# Altium schematic sheet PROCESSOR.SchDoc
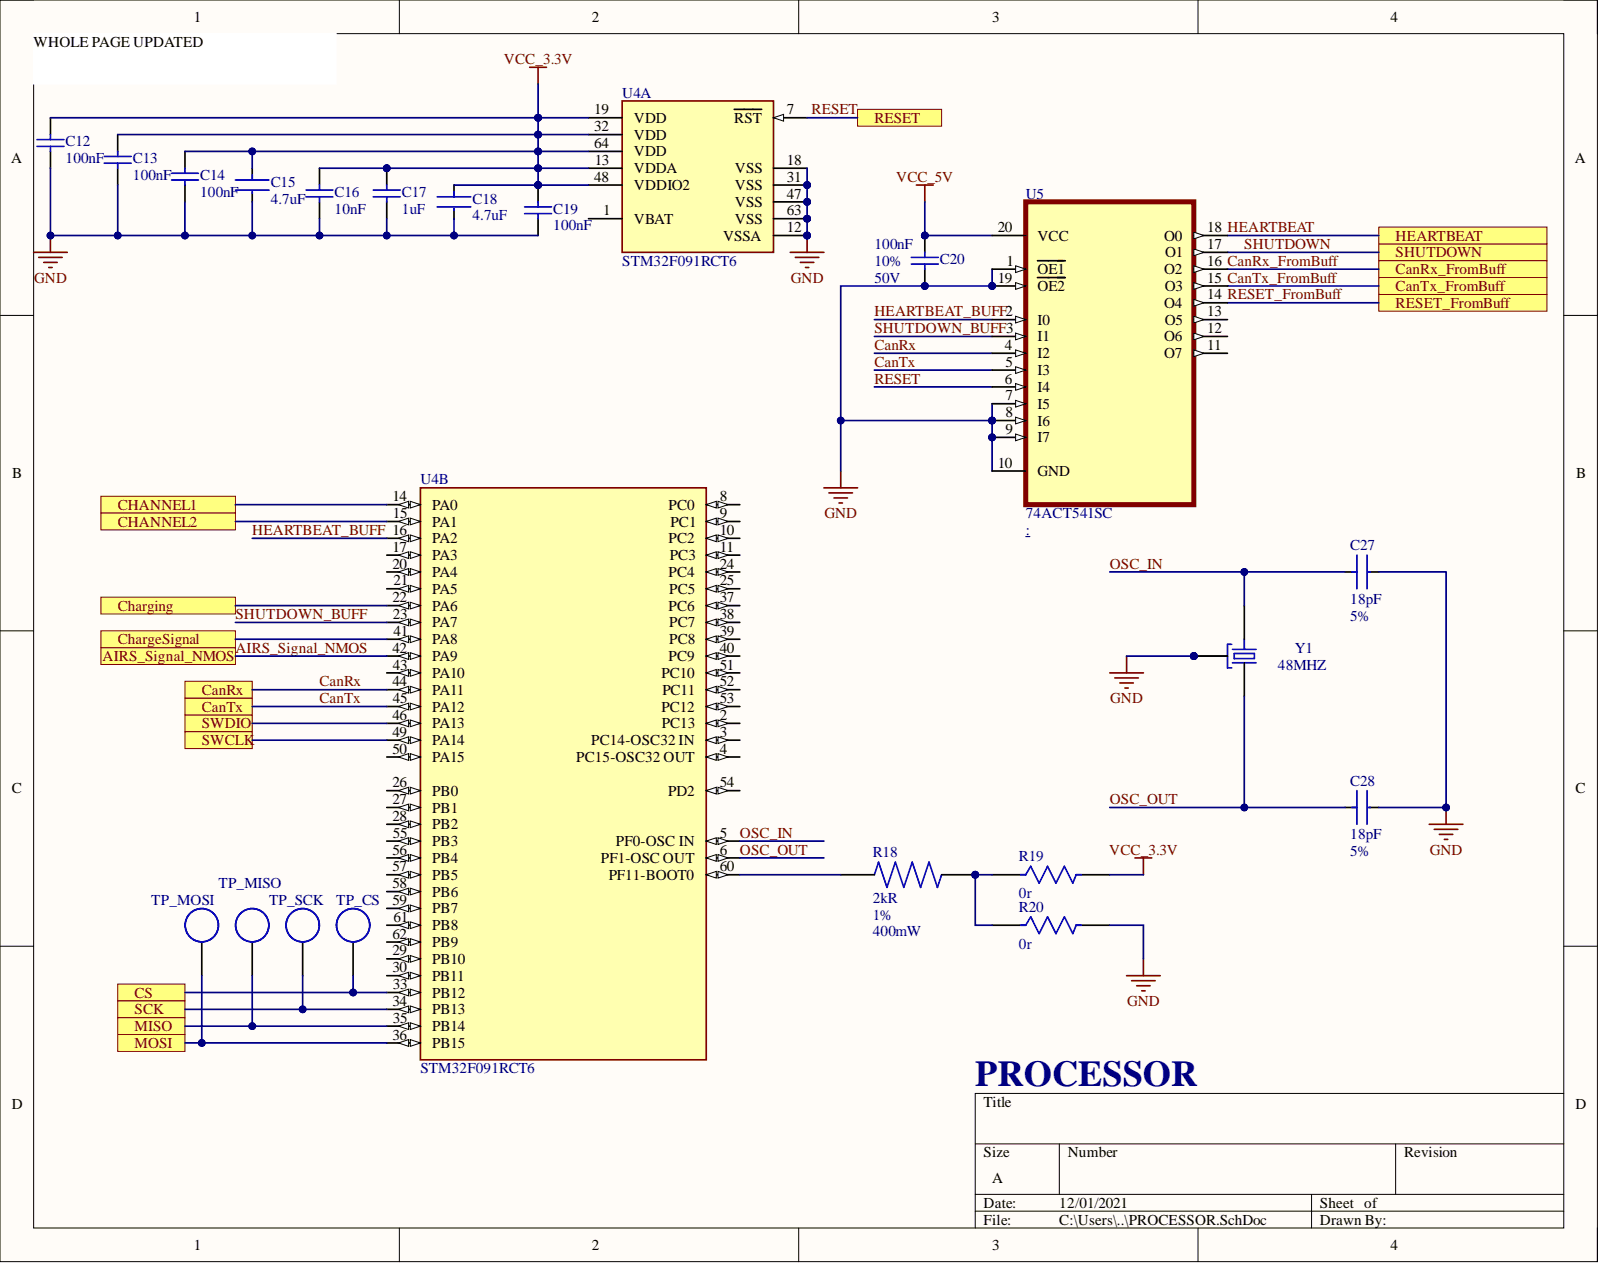
[diagram p0: PROCESSOR.SchDoc]

## Parts
C12  100nF  [CAPC2013X145X45NL30T25]
C13  100nF  [CAPC2013X145X45NL30T25]
C14  100nF  [CAPC2013X145X45NL30T25]
C15  4.7uF  [FP-GCM21B-0_15-IPC_A]
C16  10nF  [CAPC2013X70X45LL15T25]
C17  1uF  [CAPC2013X70X45NL10T25]
C18  4.7uF  [FP-GCM21B-0_15-IPC_A]
C19  100nF  [CAPC2013X145X45NL30T25]
C20  100nF  Tolerance: 10%  Voltage Rating: 50V  [CAPC2013X145X45NL30T25]
C27  18pF  Tolerance: 5%  [FP-GQM219-0_15-MFG]
C28  18pF  Tolerance: 5%  [FP-GQM219-0_15-MFG]
R18  Resistance: 2kR  Tolerance: 1%  [RESC2012X50N]  (hidden comment: 2k, 1%, 0.4W)
R19  0r  [FP-CRCW0805-HPe3-IPC_A]
R20  0r  [FP-CRCW0805-HPe3-IPC_A]
TP_CS  5015  [5015]  (value not drawn)
TP_MISO  5015  [5015]  (value not drawn)
TP_MOSI  5015  [5015]  (value not drawn)
TP_SCK  5015  [5015]  (value not drawn)
U4  STM32F091RCT6  [TQFP64]  (parts A,B)
U5  74ACT541SC  [SOIC127P1032X265-20N]
Y1  48MHZ  [OSCCC500X320X110-4N]

## Ports
AIRS_Signal_NMOS, CanRx, CanRx_FromBuff, CanTx, CanTx_FromBuff, CHANNEL1, CHANNEL2, ChargeSignal, Charging, CS, HEARTBEAT, MISO, MOSI, RESET, RESET_FromBuff, SCK, SHUTDOWN, SWCLK, SWDIO

## Nets
GND: C12.1 C13.1 C14.1 C15.2 C16.1 C17.1 C18.2 C19.1 C20.1 C27.2 C28.2 R20.2 U4.12(VSSA) U4.18(VSS) U4.31(VSS) U4.47(VSS) U4.63(VSS) U5.1(OE1) U5.7(I5) U5.8(I6) U5.9(I7) U5.10(GND) U5.19(OE2) Y1.2 Y1.4
VCC_3.3V: C12.2 C13.2 C14.2 C15.1 C16.2 C17.2 C18.1 C19.2 R19.2 U4.13(VDDA) U4.19(VDD) U4.32(VDD) U4.48(VDDIO2) U4.64(VDD)
VCC_5V: C20.2 U5.20(VCC)
AIRS_Signal_NMOS: U4.42(PA9) ; port AIRS_Signal_NMOS
CanRx: U4.44(PA11) U5.4(I2) ; port CanRx
CanRx_FromBuff: U5.16(O2) ; port CanRx_FromBuff
CanTx: U4.45(PA12) U5.5(I3) ; port CanTx
CanTx_FromBuff: U5.15(O3) ; port CanTx_FromBuff
CHANNEL1: U4.14(PA0) ; port CHANNEL1
CHANNEL2: U4.15(PA1) ; port CHANNEL2
ChargeSignal: U4.41(PA8) ; port ChargeSignal
Charging: U4.22(PA6) ; port Charging
CS: TP_CS.1 U4.33(PB12) ; port CS
HEARTBEAT: U5.18(O0) ; port HEARTBEAT
HEARTBEAT_BUFF: U4.16(PA2) U5.2(I0)
MISO: TP_MISO.1 U4.35(PB14) ; port MISO
MOSI: TP_MOSI.1 U4.36(PB15) ; port MOSI
OSC_IN: C27.1 U4.5(PF0-OSC_IN) Y1.1
OSC_OUT: C28.1 U4.6(PF1-OSC_OUT) Y1.3
RESET: U4.7(RST) U5.6(I4) ; port RESET
RESET_FromBuff: U5.14(O4) ; port RESET_FromBuff
SCK: TP_SCK.1 U4.34(PB13) ; port SCK
SHUTDOWN: U5.17(O1) ; port SHUTDOWN
SHUTDOWN_BUFF: U4.23(PA7) U5.3(I1)
SWCLK: U4.49(PA14) ; port SWCLK
SWDIO: U4.46(PA13) ; port SWDIO
NetR18_1: R18.1 U4.60(PF11-BOOT0)
NetR18_2: R18.2 R19.1 R20.1

Not connected: U4 1(VBAT) 2(PC13) 3(PC14-OSC32_IN) 4(PC15-OSC32_OUT) 8(PC0) 9(PC1) 10(PC2) 11(PC3) 17(PA3) 20(PA4) 21(PA5) 24(PC4) 25(PC5) 26(PB0) 27(PB1) 28(PB2) 29(PB10) 30(PB11) 37(PC6) 38(PC7) 39(PC8) 40(PC9) 43(PA10) 50(PA15) 51(PC10) 52(PC11) 53(PC12) 54(PD2) 55(PB3) 56(PB4) 57(PB5) 58(PB6) 59(PB7) 61(PB8) 62(PB9); U5 11(O7) 12(O6) 13(O5)

## Library data of the parts
C12-C14 C19 = CMP-2007-03212-1: Manufacturer: Murata; Manufacturer Part Number 1: GCJ21BR71H104KA01L; Dielectric: X7R; Tolerance: 10%; Voltage Rating: 50V; Capacitance: 100nF; Case Code (Imperial): 0805; Case Code (Metric): 2012; Case/Package: 0805; Category: Ceramic Capacitors; Component Kind: Standard; ComponentLink1Description: https://datasheet.ciiva.com/17453/product-17453782.pdf?src-supplier=Avnet+Europe; ComponentLink1URL: https://datasheet.ciiva.com/17453/product-17453782.pdf?src-supplier=Avnet+Europe; ComponentLink2Description: https://datasheet.ciiva.com/18183/getdatasheetpartid-663717-18183469.pdf?src-supplier=Verical; ComponentLink2URL: https://datasheet.ciiva.com/18183/getdatasheetpartid-663717-18183469.pdf?src-supplier=Verical; ComponentLink3Description: https://datasheet.ciiva.com/136/s0717e-136749.pdf?src-supplier=Digi-Key; ComponentLink3URL: https://datasheet.ciiva.com/136/s0717e-136749.pdf?src-supplier=Digi-Key; ComponentLink4Description: https://datasheet.ciiva.com/136/s0717e-136750.pdf?src-supplier=Digi-Key; ComponentLink4URL: https://datasheet.ciiva.com/136/s0717e-136750.pdf?src-supplier=Digi-Key; ComponentLink5Description: https://datasheet.ciiva.com/136/s0717e-136751.pdf?src-supplier=Digi-Key; ComponentLink5URL: https://datasheet.ciiva.com/136/s0717e-136751.pdf?src-supplier=Digi-Key; Construction: Flat; Depth: 1.25mm; Features: Soft Termination; Height: 1.25mm; Lead Free: Lead Free; Length: 2mm; Library Name: Altium Content Vault; Manufacturer 1: Murata; Max Operating Temperature: 125°C; Min Operating Temperature: -55°C; Mount: Surface Mount; Package Quantity: 3000; Packaging: Tape and Reel; Part Number: GCJ21BR71H104KA01L; Pricing 1: 1=0.48000, 10=0.34200, 50=0.25880, 100=0.22540, 500=0.16698, 1000=0.14193 (USD); Radiation Hardening: No; Ratings: AEC-Q200; RoHS: Yes; RoHS Compliant: Yes; Series: GCJ; Stock 1: 105376; Supplier 1: Digi-Key; Supplier Part Number 1: 490-5803-1-ND; Termination: SMD/SMT; Thickness: 0.057inch; Voltage Rating (DC): 50V; Width: 0.049inch
C15 C18 = CMP-2007-03343-2: Ceramic Capacitor for Automotive 4.7uF ±10% 16VDC X7R 0805 Embossed T/R; Manufacturer 1: Murata; Manufacturer Part Number 1: GCM21BR71C475KA73L; Voltage: 16V; Capacitance: 4.7uF; Case Code (Imperial): 0805; Case Code (Metric): 2012; Case/Package: 0805; Category: Ceramic Capacitors; Component Kind: Standard; ComponentLink1Description: https://datasheet.ciiva.com/11613/product-11613410.pdf?src-supplier=Avnet; ComponentLink1URL: https://datasheet.ciiva.com/11613/product-11613410.pdf?src-supplier=Avnet; ComponentLink2Description: https://datasheet.ciiva.com/11787/0900766b80ef92d0-11787002.pdf?src-supplier=RSComponents; ComponentLink2URL: https://datasheet.ciiva.com/11787/0900766b80ef92d0-11787002.pdf?src-supplier=RSComponents; ComponentLink3Description: https://datasheet.ciiva.com/140/s0717e-140483.pdf?src-supplier=Digi-Key; ComponentLink3URL: https://datasheet.ciiva.com/140/s0717e-140483.pdf?src-supplier=Digi-Key; ComponentLink4Description: https://datasheet.ciiva.com/140/s0717e-140484.pdf?src-supplier=Digi-Key; ComponentLink4URL: https://datasheet.ciiva.com/140/s0717e-140484.pdf?src-supplier=Digi-Key; Construction: Flat; Depth: 1.25mm; Dielectric: X7R; Height: 1.25mm; Lead Free: Lead Free; Length: 2mm; Library Name: Altium Content Vault; Max Operating Temperature: 125°C; Min Operating Temperature: -55°C; Mount: Surface Mount; Package Quantity: 1; Packaging: Tape and Reel; Pricing 1: 1=0.37000, 10=0.25300, 50=0.16900, 100=0.14360, 500=0.10138, 1000=0.08870 (USD); Radiation Hardening: No; Ratings: AEC-Q200; REACH SVHC: No SVHC; RoHS: Yes; RoHS Compliant: Yes; Series: GCM; Stock 1: 382617; Supplier 1: Digi-Key; Supplier Part Number 1: 490-5332-1-ND; Termination: SMD/SMT; Thickness: 0.055inch; Tolerance: 10%; Voltage Rating: 16V; Voltage Rating (DC): 16V; Weight: 0.000335oz; Width: 0.049inch
C16 = CMP-2007-00806-1: Manufacturer: Murata; Manufacturer Part Number 1: GCM216R72A103KA37D; Dielectric: X7R; Tolerance: 10%; Voltage: 100V; Capacitance: 10nF; Case Code (Imperial): 0805; Case Code (Metric): 2012; Case/Package: 0805; Category: Ceramic Capacitors; Component Kind: Standard; ComponentLink1Description: https://datasheet.ciiva.com/11620/product-11620072.pdf?src-supplier=Avnet; ComponentLink1URL: https://datasheet.ciiva.com/11620/product-11620072.pdf?src-supplier=Avnet; ComponentLink2Description: https://datasheet.ciiva.com/18183/getdatasheetpartid-223896-18183680.pdf?src-supplier=Verical; ComponentLink2URL: https://datasheet.ciiva.com/18183/getdatasheetpartid-223896-18183680.pdf?src-supplier=Verical; ComponentLink3Description: https://datasheet.ciiva.com/6270/s0717e-6270558.pdf?src-supplier=Digi-Key; ComponentLink3URL: https://datasheet.ciiva.com/6270/s0717e-6270558.pdf?src-supplier=Digi-Key; ComponentLink4Description: https://datasheet.ciiva.com/16214/s0717e-16214647.pdf?src-supplier=Future+Electronics; ComponentLink4URL: https://datasheet.ciiva.com/16214/s0717e-16214647.pdf?src-supplier=Future+Electronics; Construction: Flat; Depth: 1.25mm; Height: 0.6mm; Lead Free: Lead Free; Length: 2mm; Library Name: Altium Content Vault; Manufacturer 1: Murata; Max Operating Temperature: 125°C; Min Operating Temperature: -55°C; Mount: Surface Mount; Package Quantity: 1; Packaging: Tape and Reel; Part Number: GCM216R72A103KA37D; Pricing 1: 1=0.36000, 10=0.24900, 50=0.16640, 100=0.14140, 500=0.09980, 1000=0.08732 (USD); Radiation Hardening: No; Ratings: AEC-Q200; RoHS: Yes; RoHS Compliant: Yes; Series: GCM; Stock 1: 2316; Supplier 1: Digi-Key; Supplier Part Number 1: 490-6060-1-ND; Termination: SMD/SMT; Thickness: 0.028inch; Voltage Rating: 100V; Voltage Rating (DC): 100V; Width: 0.049inch
C17 = CMP-2007-00957-1: Manufacturer: Murata; Manufacturer Part Number 1: GRM216R61E105KA12D; Dielectric: X5R; Material: Ceramic; Tolerance: 10%; Voltage: 25V; Capacitance: 1uF; Case Code (Imperial): 0805; Case Code (Metric): 2012; Case/Package: 0805; Category: Ceramic Capacitors; Component Kind: Standard; ComponentLink1Description: https://datasheet.ciiva.com/5424/product-5424880.pdf?src-supplier=Mouser; ComponentLink1URL: https://datasheet.ciiva.com/5424/product-5424880.pdf?src-supplier=Mouser; ComponentLink2Description: https://datasheet.ciiva.com/3777/337grm216r61e105ka1223-3777289.pdf?src-supplier=Arrow+Electronics; ComponentLink2URL: https://datasheet.ciiva.com/3777/337grm216r61e105ka1223-3777289.pdf?src-supplier=Arrow+Electronics; ComponentLink3Description: https://datasheet.ciiva.com/11787/0900766b80ef921d-11787891.pdf?src-supplier=RSComponents; ComponentLink3URL: https://datasheet.ciiva.com/11787/0900766b80ef921d-11787891.pdf?src-supplier=RSComponents; ComponentLink4Description: https://datasheet.ciiva.com/138/partnumbering-e-01-138517.pdf?src-supplier=Digi-Key; ComponentLink4URL: https://datasheet.ciiva.com/138/partnumbering-e-01-138517.pdf?src-supplier=Digi-Key; ComponentLink5Description: https://datasheet.ciiva.com/138/partnumbering-e-01-138519.pdf?src-supplier=Digi-Key; ComponentLink5URL: https://datasheet.ciiva.com/138/partnumbering-e-01-138519.pdf?src-supplier=Digi-Key; ComponentLink6Description: https://datasheet.ciiva.com/138/partnumbering-e-01-138518.pdf?src-supplier=Digi-Key; ComponentLink6URL: https://datasheet.ciiva.com/138/partnumbering-e-01-138518.pdf?src-supplier=Digi-Key; ComponentLink7Description: https://datasheet.ciiva.com/2989/product-2989638.pdf?src-supplier=Avnet; ComponentLink7URL: https://datasheet.ciiva.com/2989/product-2989638.pdf?src-supplier=Avnet; ComponentLink8Description: https://datasheet.ciiva.com/22706/1889704-22706791.pdf?src-supplier=Element14; ComponentLink8URL: https://datasheet.ciiva.com/22706/1889704-22706791.pdf?src-supplier=Element14; ComponentLink9Description: https://datasheet.ciiva.com/7719/c02e-7719049.pdf?src-supplier=Element14; ComponentLink9URL: https://datasheet.ciiva.com/7719/c02e-7719049.pdf?src-supplier=Element14; Construction: Flat; Depth: 1.25mm; Height: 0.6mm; Lead Free: Lead Free; Length: 2mm; Library Name: Altium Content Vault; Manufacturer 1: Murata; Max Operating Temperature: 85°C; Min Operating Temperature: -55°C; Mount: Surface Mount; Number of Pins: 2; Package Quantity: 1; Packaging: Tape and Reel; Part Number: GRM216R61E105KA12D; Pricing 1: 1=0.40000, 10=0.27800, 50=0.19480, 100=0.16700, 500=0.12524, 1000=0.10575 (USD); Radiation Hardening: No; REACH SVHC: No SVHC; RoHS: Yes; RoHS Compliant: Yes; Series: GRM; Stock 1: 839705; Supplier 1: Digi-Key; Supplier Part Number 1: 490-1700-1-ND; Termination: SMD/SMT; Thickness: 0.028inch; Voltage Rating: 25V; Voltage Rating (DC): 25V; Width: 1.25mm
C20 = CMP-2007-03212-1: Manufacturer: Murata; Manufacturer Part Number 1: GCJ21BR71H104KA01L; Dielectric: X7R; Capacitance: 100nF; Case Code (Imperial): 0805; Case Code (Metric): 2012; Case/Package: 0805; Category: Ceramic Capacitors; Component Kind: Standard; ComponentLink1Description: https://datasheet.ciiva.com/17453/product-17453782.pdf?src-supplier=Avnet+Europe; ComponentLink1URL: https://datasheet.ciiva.com/17453/product-17453782.pdf?src-supplier=Avnet+Europe; ComponentLink2Description: https://datasheet.ciiva.com/18183/getdatasheetpartid-663717-18183469.pdf?src-supplier=Verical; ComponentLink2URL: https://datasheet.ciiva.com/18183/getdatasheetpartid-663717-18183469.pdf?src-supplier=Verical; ComponentLink3Description: https://datasheet.ciiva.com/136/s0717e-136749.pdf?src-supplier=Digi-Key; ComponentLink3URL: https://datasheet.ciiva.com/136/s0717e-136749.pdf?src-supplier=Digi-Key; ComponentLink4Description: https://datasheet.ciiva.com/136/s0717e-136750.pdf?src-supplier=Digi-Key; ComponentLink4URL: https://datasheet.ciiva.com/136/s0717e-136750.pdf?src-supplier=Digi-Key; ComponentLink5Description: https://datasheet.ciiva.com/136/s0717e-136751.pdf?src-supplier=Digi-Key; ComponentLink5URL: https://datasheet.ciiva.com/136/s0717e-136751.pdf?src-supplier=Digi-Key; Construction: Flat; Depth: 1.25mm; Features: Soft Termination; Height: 1.25mm; Lead Free: Lead Free; Length: 2mm; Library Name: Altium Content Vault; Manufacturer 1: Murata; Max Operating Temperature: 125°C; Min Operating Temperature: -55°C; Mount: Surface Mount; Package Quantity: 3000; Packaging: Tape and Reel; Part Number: GCJ21BR71H104KA01L; Pricing 1: 1=0.48000, 10=0.34200, 50=0.25880, 100=0.22540, 500=0.16698, 1000=0.14193 (USD); Radiation Hardening: No; Ratings: AEC-Q200; RoHS: Yes; RoHS Compliant: Yes; Series: GCJ; Stock 1: 105376; Supplier 1: Digi-Key; Supplier Part Number 1: 490-5803-1-ND; Termination: SMD/SMT; Thickness: 0.057inch; Voltage Rating (DC): 50V; Width: 0.049inch
C27 C28 = CMP-2007-03884-2: Ceramic Capacitor 18pF ±5% 250VDC C0G 0805 Paper T/R; Manufacturer 1: Murata; Manufacturer Part Number 1: GQM2195C2E180JB12D; Voltage Rating: 250V; Capacitance: 18pF; Case Code (Imperial): 0805; Case Code (Metric): 2012; Case/Package: 0805; Category: Ceramic Capacitors; Component Kind: Standard; ComponentLink1Description: https://datasheet.ciiva.com/5420/product-5420568.pdf?src-supplier=Mouser; ComponentLink1URL: https://datasheet.ciiva.com/5420/product-5420568.pdf?src-supplier=Mouser; ComponentLink2Description: https://datasheet.ciiva.com/142/partnumbering-e-01-142348.pdf?src-supplier=Digi-Key; ComponentLink2URL: https://datasheet.ciiva.com/142/partnumbering-e-01-142348.pdf?src-supplier=Digi-Key; ComponentLink3Description: https://datasheet.ciiva.com/142/partnumbering-e-01-142349.pdf?src-supplier=Digi-Key; ComponentLink3URL: https://datasheet.ciiva.com/142/partnumbering-e-01-142349.pdf?src-supplier=Digi-Key; ComponentLink4Description: https://datasheet.ciiva.com/142/partnumbering-e-01-142350.pdf?src-supplier=Digi-Key; ComponentLink4URL: https://datasheet.ciiva.com/142/partnumbering-e-01-142350.pdf?src-supplier=Digi-Key; ComponentLink5Description: https://datasheet.ciiva.com/11607/product-11607802.pdf?src-supplier=Avnet; ComponentLink5URL: https://datasheet.ciiva.com/11607/product-11607802.pdf?src-supplier=Avnet; Construction: Flat; Depth: 1.25mm; Dielectric: C0G; Height: 0.85mm; Length: 2mm; Library Name: Altium Content Vault; Max Operating Temperature: 125°C; Min Operating Temperature: -55°C; Mount: Surface Mount; Package Quantity: 1; Packaging: Tape and Reel; Pricing 1: 1=1.00000, 10=0.75900, 50=0.63080, 100=0.54320, 500=0.42056, 1000=0.36214 (USD); Radiation Hardening: No; RoHS: Yes; RoHS Compliant: Yes; Series: GQM; Stock 1: 3729; Supplier 1: Digi-Key; Supplier Part Number 1: 490-5297-1-ND; Termination: SMD/SMT; Thickness: 0.039inch; Voltage Rating (DC): 250V; Width: 1.25mm
R18 = RCS08052K00FKEA: Res Thick Film 0805 2K Ohm 1% 0.4W ±100ppm/C Pad SMD Automotive T/R; Manufacturer 1: Vishay Dale; Max Power Dissipation: 400mW; Temperature Coefficient: 100ppm/°C; Availability: Unavailable; Case Code (Imperial): 0805; Case Code (Metric): 2012; Case/Package: 0805; Category: Chip SMD Resistors; Component Kind: Standard; ComponentLink1Description: http://www.vishay.com/docs/20065/rcse3.pdf?src-supplier=Digi-Key; ComponentLink1URL: http://www.vishay.com/docs/20065/rcse3.pdf?src-supplier=Digi-Key; Features: Automotive AEC-Q200; Height: 0.02inch; Length: 0.079inch; Manufacturer Part Number 1: RCS08052K00FKEA; Max Operating Temperature: 155°C; Min Operating Temperature: -55°C; MP: RCS08052K00FKEA; Number of Terminations: 2; Package: None; Packaging: Tape and Reel; Price: None; Pricing 1: 1=0.26000, 10=0.21800, 100=0.08510, 1000=0.03560, 2500=0.03264 (USD); Resistor Type: Thick Film; RoHS: Yes; Stock 1: 4243; Supplier 1: Digi-Key; Supplier Part Number 1: 541-2840-1-ND; Width: 0.049inch; Library Name: BMS_MasterboardUpdated_1.SCHLIB
R19 R20 = CMP-2001-04185-2: RES Thick Film, 0Ω, 0.5W, 0805; Manufacturer 1: Vishay Dale; Manufacturer Part Number 1: CRCW08050000Z0EAHP; Max Power Dissipation: 500mW; Power Rating: 330mW; Resistance: 0mR; Temperature Coefficient: 0ppm/°C; Tolerance: 5%; Voltage Rating: 150V; Case Code (Imperial): 0805; Case Code (Metric): 2012; Case/Package: 0805; Category: Chip SMD Resistors; Component Kind: Standard; ComponentLink1Description: https://datasheet.ciiva.com/2474/crcwhpe3-2474632.pdf?src-supplier=Digi-Key; ComponentLink1URL: https://datasheet.ciiva.com/2474/crcwhpe3-2474632.pdf?src-supplier=Digi-Key; ComponentLink2Description: https://datasheet.ciiva.com/2474/crcwhpe3-2474633.pdf?src-supplier=Digi-Key; ComponentLink2URL: https://datasheet.ciiva.com/2474/crcwhpe3-2474633.pdf?src-supplier=Digi-Key; ComponentLink3Description: https://datasheet.ciiva.com/2474/crcwhpe3-2474634.pdf?src-supplier=Digi-Key; ComponentLink3URL: https://datasheet.ciiva.com/2474/crcwhpe3-2474634.pdf?src-supplier=Digi-Key; ComponentLink4Description: https://datasheet.ciiva.com/9109/crcwhpe3-9109934.pdf?src-supplier=Avnet; ComponentLink4URL: https://datasheet.ciiva.com/9109/crcwhpe3-9109934.pdf?src-supplier=Avnet; ComponentLink5Description: https://datasheet.ciiva.com/5549/crcwhpe3-46056-5549241.pdf?src-supplier=Mouser; ComponentLink5URL: https://datasheet.ciiva.com/5549/crcwhpe3-46056-5549241.pdf?src-supplier=Mouser; ComponentLink6Description: https://datasheet.ciiva.com/16883/crcwhpe3-16883643.pdf?src-supplier=Avnet+Europe; ComponentLink6URL: https://datasheet.ciiva.com/16883/crcwhpe3-16883643.pdf?src-supplier=Avnet+Europe; ComponentLink7Description: https://datasheet.ciiva.com/16498/crcwhpe3-16498294.pdf?src-supplier=Future+Electronics; ComponentLink7URL: https://datasheet.ciiva.com/16498/crcwhpe3-16498294.pdf?src-supplier=Future+Electronics; ComponentLink8Description: https://datasheet.ciiva.com/28621/1750852-28621406.pdf?src-supplier=Element14; ComponentLink8URL: https://datasheet.ciiva.com/28621/1750852-28621406.pdf?src-supplier=Element14; ComponentLink9Description: https://datasheet.ciiva.com/7859/crcwhpe3-7859727.pdf?src-supplier=Element14; ComponentLink9URL: https://datasheet.ciiva.com/7859/crcwhpe3-7859727.pdf?src-supplier=Element14; Composition: Thick Film; Construction: Rectangular; Contact Plating: Tin; Depth: 1.25mm; Features: Automotive AEC-Q200; Height: 0.024inch; Lead Free: Lead Free; Length: 2mm; Library Name: Altium Content Vault; Max Operating Temperature: 155°C; Military Standard: Not; Min Operating Temperature: -55°C; Mount: Surface Mount; Number of Pins: 2; Number of Terminations: 2; Package Quantity: 5000; Packaging: Tape and Reel; Pricing 1: 1=0.21000, 10=0.17600, 25=0.12800, 50=0.09280, 100=0.06880, 250=0.05280, 500=0.04160, 1000=0.02880 (USD); Radiation Hardening: No; REACH SVHC: No SVHC; Resistor Type: Thick Film; RoHS: Yes; RoHS Compliant: Yes; Series: CRCW-HP; Size Code: 0805; Stock 1: 60898; Supplier 1: Digi-Key; Supplier Part Number 1: 541-0.0TBCT-ND; Technology: THICK FILM; Termination: Solder; Voltage Rating (DC): 150V; Weight: 0.000194oz; Width: 0.049inch
TP_CS TP_MISO TP_MOSI TP_SCK = 5015: SMD Test Point; Manufacturer: Keystone Electronics; TargetLibrary: RITRacing-Connectors; Component Kind: Standard; ComponentLink1Description: Datasheet; ComponentLink1URL: http://www.mouser.com/ds/2/215/005-5009-743680.pdf; Library Name: Connectors.IntLib; PartNumber: 5015; Published: 22Oct2016; Status: Active; Publisher: T.Tallo
U4 = CMP-12105-000069-1: IC MCU 32BIT 256KB FLASH 64LQFP; Manufacturer: STMicroelectronics; Core Architecture: ARM Cortex-M0; Data Bus Width: 32bits; Frequency: 48MHz; Interface: I2C,SPI,USART,CAN,LIN,IrDA,I2S,SMBus; Max Junction Temp: +105°C; Max Supply Voltage: 3.6V; Memory Size: 256KBytes; Min Supply Voltage: 2V; Nominal Supply Current: 0.9-26.9mA; Number Of A/D Converters: 1; Number Of Adc Channels: 19; Number Of I2C Channels: 2; Number Of I/Os: 52; Number Of Pwm Channels: 6; Number Of Timers/Counters: 10; Number Of Uart Channels: 8; Ram Size: 32KBytes; Standoff Height: 0.05mm; Ambient Temperature Range High: +85°C; Ambient Temperature Range Low: -40°C; Automotive: No; Category: IC; Component Kind: Standard; ComponentLink2Description: Datasheet; ComponentLink2URL: https://www.st.com/resource/en/datasheet/stm32f091rc.pdf; Device Class L1: Integrated Circuits (ICs); Device Class L2: Embedded Processors and Controllers; Device Class L3: Microcontrollers; Digikey Description: IC MCU 32BIT 256KB FLASH 64LQFP; Height: 1.6mm; Ipc Land Pattern Name: QFP50P1200X1200X160-64; Lead Free: Yes; Manufacturer Part Number: STM32F091RCT6; Memory Type: FLASH; Mouser Description: ARM Microcontrollers - MCU 16/32-BITS MICROS; Number Of Cores: 1; Number Of Spi Channels: 2; Octopart: https://octopart.com/stm32f091rct6-stmicroelectronics-51988683; Package: LQFP64-5W; RoHS: TRUE; Supplier 1: Digi-Key; Supplier Part Number 1: 497-15167-ND; Temperature: -40°C to +85°C; Temperature Range High: +85°C; Temperature Range Low: -40°C; Library Name: BMS_MasterboardUpdated.IntLib
U5 = 74ACT541SC: Buffer, Non-Inverting 1 Element 8 Bit per Element 3-State Output 20-SOIC; MF: ON Semiconductor; Arrow_Electronics-Purchase-URL: https://snapeda.com/shop?store=Arrow+Electronics&id=431052; Availability: Warning; Component Kind: Standard; DigiKey-Purchase-URL: https://snapeda.com/shop?store=DigiKey&id=431052; Mouser-Purchase-URL: https://snapeda.com/shop?store=Mouser&id=431052; MP: 74ACT541SC; Package: SOIC-20 Fairchild Semiconductor; Price: None; SnapEDA_Link: https://www.snapeda.com/parts/74ACT541SC/ON%20Semiconductor/view-part/431052/?ref=snap; Supplier 1: Digi-Key; Supplier Part Number 1: 74ACT541SC-ND; Library Name: BMS_MasterboardUpdated_1.SCHLIB
Y1 = ABM3B-48.000MHZ-B2-T: CRYSTAL, 48MHZ, 18PF, 5 X 3.2MM; Product Range:ABM3B Series; Frequency Nom:48MHz; Frequency Stability + / -:50ppm; Frequency Tolerance + / -:20ppm; Crystal Case:SMD, 5mm x 3.2mm; Load Capacitance:18pF; Operating Temperature Min:-20 C; Manufacturer 1: Abracon; ESR (Equivalent Series Resistance): 50R; Frequency Stability: 50ppm; Frequency Tolerance: 20ppm; Availability: Unavailable; Case/Package: SMD/SMT; Category: Crystals; Component Kind: Standard; ComponentLink1Description: https://datasheet.ciiva.com/4945/abm3b-39910-4945264.pdf?src-supplier=Mouser; ComponentLink1URL: https://datasheet.ciiva.com/4945/abm3b-39910-4945264.pdf?src-supplier=Mouser; ComponentLink2Description: https://datasheet.ciiva.com/855/abm3b-855707.pdf?src-supplier=Digi-Key; ComponentLink2URL: https://datasheet.ciiva.com/855/abm3b-855707.pdf?src-supplier=Digi-Key; ComponentLink3Description: https://datasheet.ciiva.com/855/abm3b-855708.pdf?src-supplier=Digi-Key; ComponentLink3URL: https://datasheet.ciiva.com/855/abm3b-855708.pdf?src-supplier=Digi-Key; ComponentLink4Description: https://datasheet.ciiva.com/855/abm3b-855709.pdf?src-supplier=Digi-Key; ComponentLink4URL: https://datasheet.ciiva.com/855/abm3b-855709.pdf?src-supplier=Digi-Key; ComponentLink5Description: https://datasheet.ciiva.com/7998/abm3b-7998585.pdf?src-supplier=Element14; ComponentLink5URL: https://datasheet.ciiva.com/7998/abm3b-7998585.pdf?src-supplier=Element14; Depth: 3.2mm; Frequency: 48MHz; Height: 0.043inch; Lead Free: Lead Free; Length: 5mm; Load Capacitance: 18pF; Manufacturer Part Number 1: ABM3B-48.000MHZ-B2-T; Max Operating Temperature: 70°C; Min Operating Temperature: -20°C; Mount: Surface Mount; MP: ABM3B-48.000MHZ-B2-T; Number of Pins: 4; Operating Frequency: 48 MHz; Package: None; Package Quantity: 1000; Packaging: Tape and Reel; Price: None; Pricing 1: 1=0.95000, 10=0.83900, 50=0.78440, 100=0.69310, 500=0.65664 (USD); REACH SVHC: No SVHC; Resistor Type: Ceramic; RoHS: Yes; Series: ABM3B; Series Resistance: 50R; Stock 1: 2963; Supplier 1: Digi-Key; Supplier Part Number 1: 535-9133-1-ND; Termination: SMD/SMT; Tolerance: 20ppm; Width: 3.2mm; Library Name: BMS_MasterboardUpdated_1.SCHLIB
